# Altium schematic sheet 09_ONB_COMPASS.SchDoc(09_ONB_COMPASS)
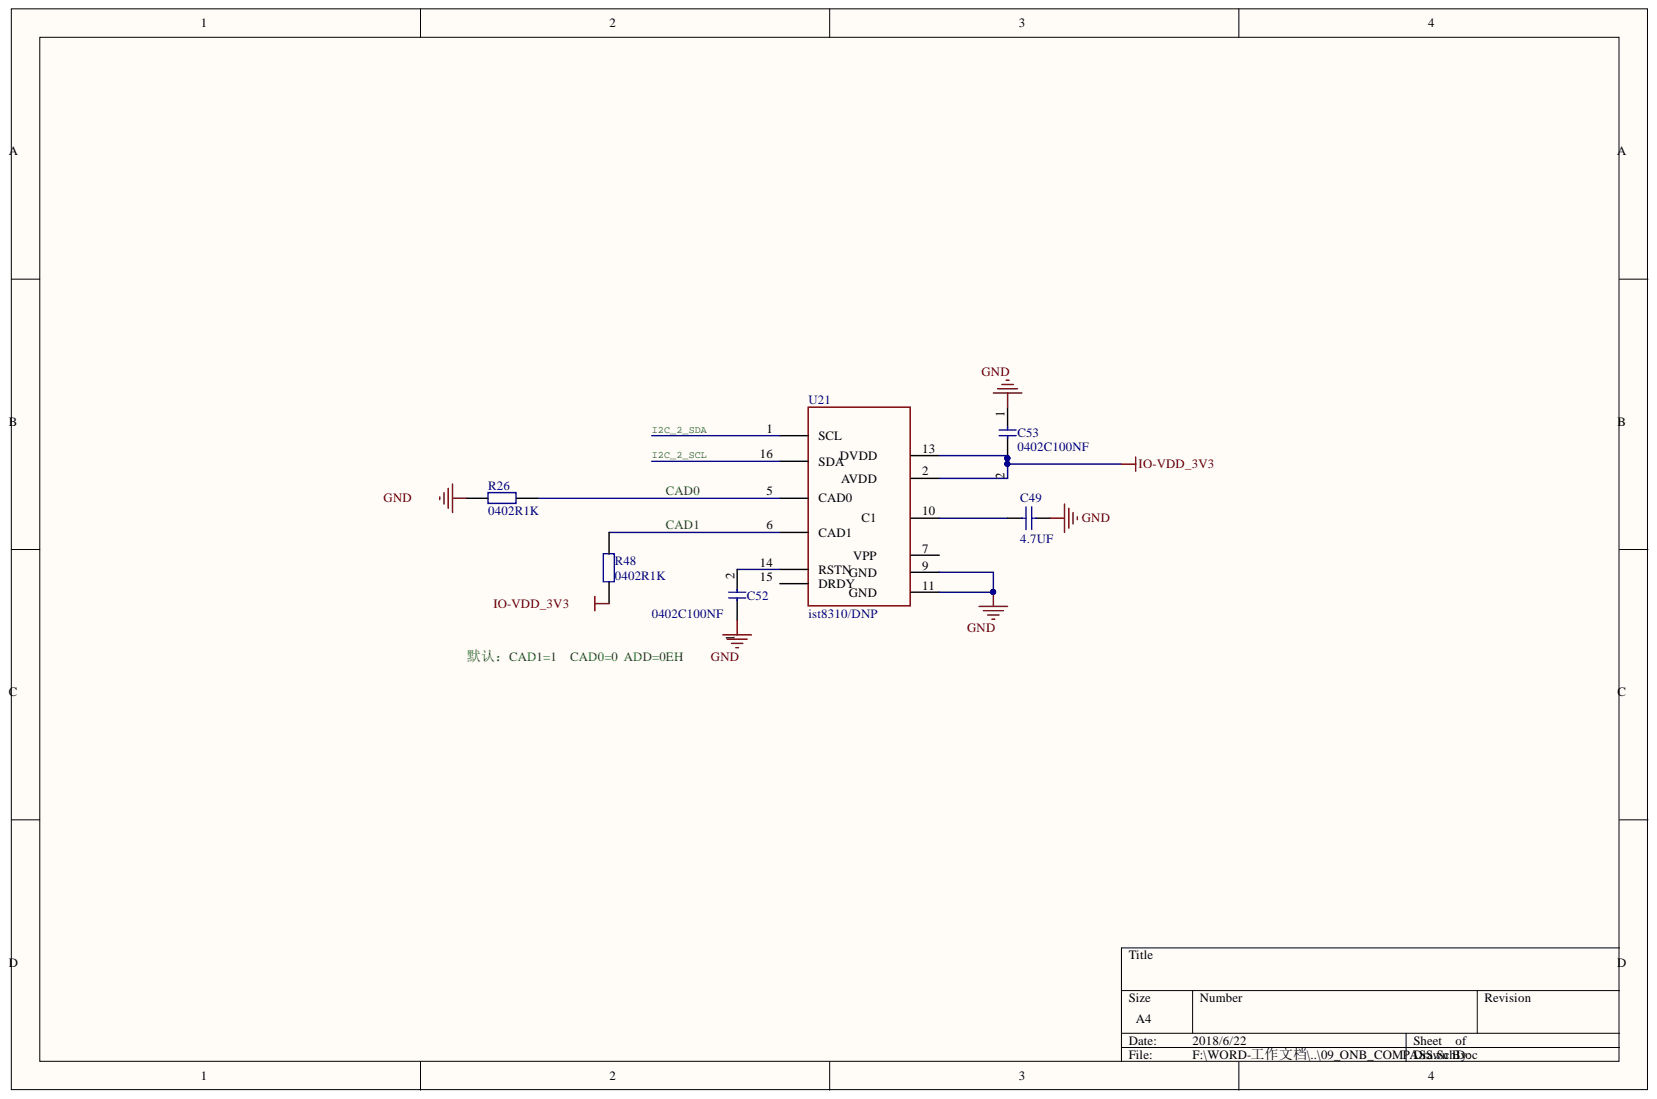
[diagram p0: 09_ONB_COMPASS.SchDoc]

## Parts
C49  4.7UF  [0805CAP]
C52  0402C100NF  [0402C]
C53  0402C100NF  [0402C]
R26  0402R1K  [0402R]
R48  0402R1K  [0402R]
U21  ist8310/DNP  [LGA16_4X4]

## Nets
GND: C49.2 C52.1 C53.1 R26.2 U21.9 U21.11
IO-VDD_3V3: C53.2 R48.1 U21.2 U21.13
CAD0: R26.1 U21.5(CAD0)
CAD1: R48.2 U21.6(CAD1)
I2C_2_SCL: U21.16(SDA)
I2C_2_SDA: U21.1(SCL)
NetC49_1: C49.1 U21.10
NetC52_2: C52.2 U21.14(RSTN)
默认：CAD1=1    CAD0=0  ADD=0EH:

Not connected: U21 7 15(DRDY)

## Library data of the parts
C49 = Cap: 0805 4.7UF 50V 10%; Component Kind: Standard; Library Name: Miscellaneous Devices.IntLib; Signal Integrity: Cap; Simulation: CAP
C52 = 0402C100NF: 0402 100nf 50V 10%; Component Kind: Standard; Library Name: CUAV.SchLib
C53 = 0402C100NF: 50V 5%; Component Kind: Standard; Library Name: CUAV.SchLib
R26 R48 = 0402R1K: 0402R1K 1%; Component Kind: Standard; Library Name: CUAV.SchLib
U21 = ist8310: Component Kind: Standard; Library Name: CUAV.SchLib
